# Altium schematic sheet Sheet3.SchDoc(Sheet3)
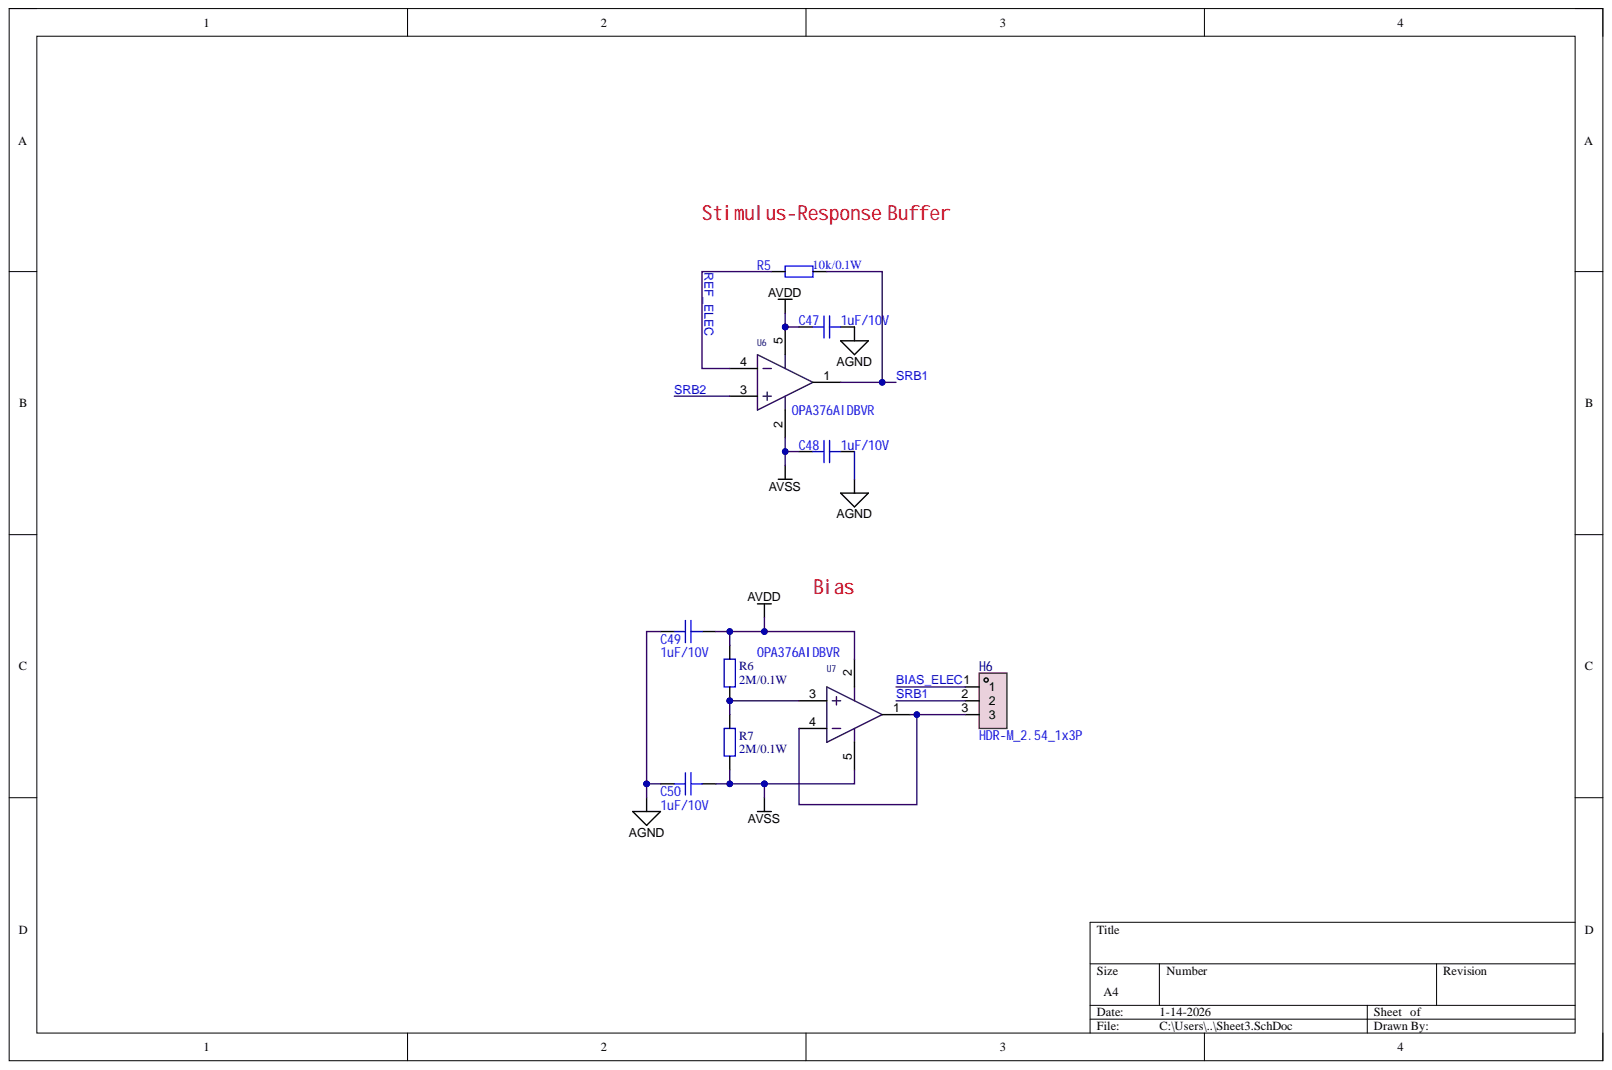
[diagram p0: Sheet3.SchDoc]

## Parts
C47  value 1uF/10V  [C0603]  (hidden comment: C0603C105K8RACAUTO7411)
C48  value 1uF/10V  [C0603]  (hidden comment: C0603C105K8RACAUTO7411)
C49  value 1uF/10V  [C0603]  (hidden comment: C0603C105K8RACAUTO7411)
C50  value 1uF/10V  [C0603]  (hidden comment: C0603C105K8RACAUTO7411)
H6  HDR-M_2.54_1x3P  [HDR-TH_3P-P2.54-V-M]
R5  value 10k/0.1W  [R0603]  (hidden comment: 10K)
R6  value 2M/0.1W  [R0603]  (hidden comment: RMCF0603FT2M00)
R7  value 2M/0.1W  [R0603]  (hidden comment: RMCF0603FT2M00)
U6  OPA376AIDBVR  [SOT-23-5_L3.0-W1.7-P0.95-LS2.8-BR]
U7  OPA376AIDBVR  [SOT-23-5_L3.0-W1.7-P0.95-LS2.8-BR]

## Nets
AGND: C47.1 C48.1 C49.2 C50.2
AVDD: C47.2 C49.1 R6.2 U6.5 U7.2
AVSS: C48.2 C50.1 R7.1 U6.2 U7.5
BIAS_ELEC: H6.1
REF_ELEC: R5.1 U6.4
SRB1: H6.2 R5.2 U6.1
SRB2: U6.3
NetH6_3: H6.3 U7.1 U7.4
NetR6_1: R6.1 R7.2 U7.3

## Library data of the parts
C47-C50 = C0603C105K8RACAUTO7411: Multilayer Ceramic Capacitors MLCC - SMD/SMT 10 Vol 1 uF 0603 X7R 10 % AECQ200; Add into BOM: yes; Device: CAP_0603; Symbol: CAP; Component Kind: Standard; ComponentLink1Description: Product Link; ComponentLink1URL: https://www.mouser.in/ProductDetail/KEMET/C0603C105K8RACAUTO7411?qs=55YtniHzbhDsN9XQhinV0w%3D%3D; Convert to PCB: yes; Library Name: US00001.SCHLIB; Supplier Footprint: 0603
H6 = HDR-M_2.54_1x3P: Add into BOM: yes; Symbol: PZ254V-11-03P_C2937625; Component Kind: Standard; Convert to PCB: yes; Device: HDR-M_2.54_1x3P; Library Name: US00001.SCHLIB; Supplier Footprint: Through
R5 = RC0603JR-0710KL: RES 10K OHM 5% 1/10W 0603; Add into BOM: yes; Device: Res_0603; Component Kind: Standard; ComponentLink1Description: Product link; ComponentLink1URL: https://www.digikey.in/en/products/detail/yageo/RC0603JR-0710KL/726700; Convert to PCB: yes; Library Name: US00001.SCHLIB; Supplier Footprint: 0603; Symbol: Res
R6 R7 = RMCF0603FT2M00: RES 2M OHM 1% 1/10W 0603; Component Kind: Standard; ComponentLink1Description: Product link; ComponentLink1URL: https://www.digikey.in/en/products/detail/stackpole-electronics-inc/RMCF0603FT2M00/1761332; Library Name: US00001.SCHLIB
U6 U7 = OPA376AIDBVR: IC OPAMP GP 1 CIRCUIT SOT23-5; Manufacturer: TI(德州仪器); Add into BOM: yes; Current - Input Bias(Ib): 0.2pA; Gain Bandwidth Product(GBP): 5.5MHz; Number of Circuits: 单路; Output Current: 50mA; Slew Rate(SR): 2V/us; Supply Current: 760uA; Component Kind: Standard; ComponentLink1Description: Product link; ComponentLink1URL: https://www.digikey.in/en/products/detail/texas-instruments/OPA376AIDBVR/1899713; Convert to PCB: yes; Datasheet: https://www.ti.com/cn/lit/gpn/opa376; Device: OPA376AIDBVR; JLCPCB Part Class: Extended Part; LCSC Part Name: OPA376AIDBVR; Library Name: US00001.SCHLIB; Manufacturer Part: OPA376AIDBVR; Operating Temperature: -40℃~+125℃; Output Type: -; Rail to Rail: -; Supplier: LCSC; Supplier Footprint: SOT-23-5; Supplier Part: C42134; Symbol: OPA376AIDBVR
